# Altium schematic sheet Sheet1.SchDoc(Sheet1)
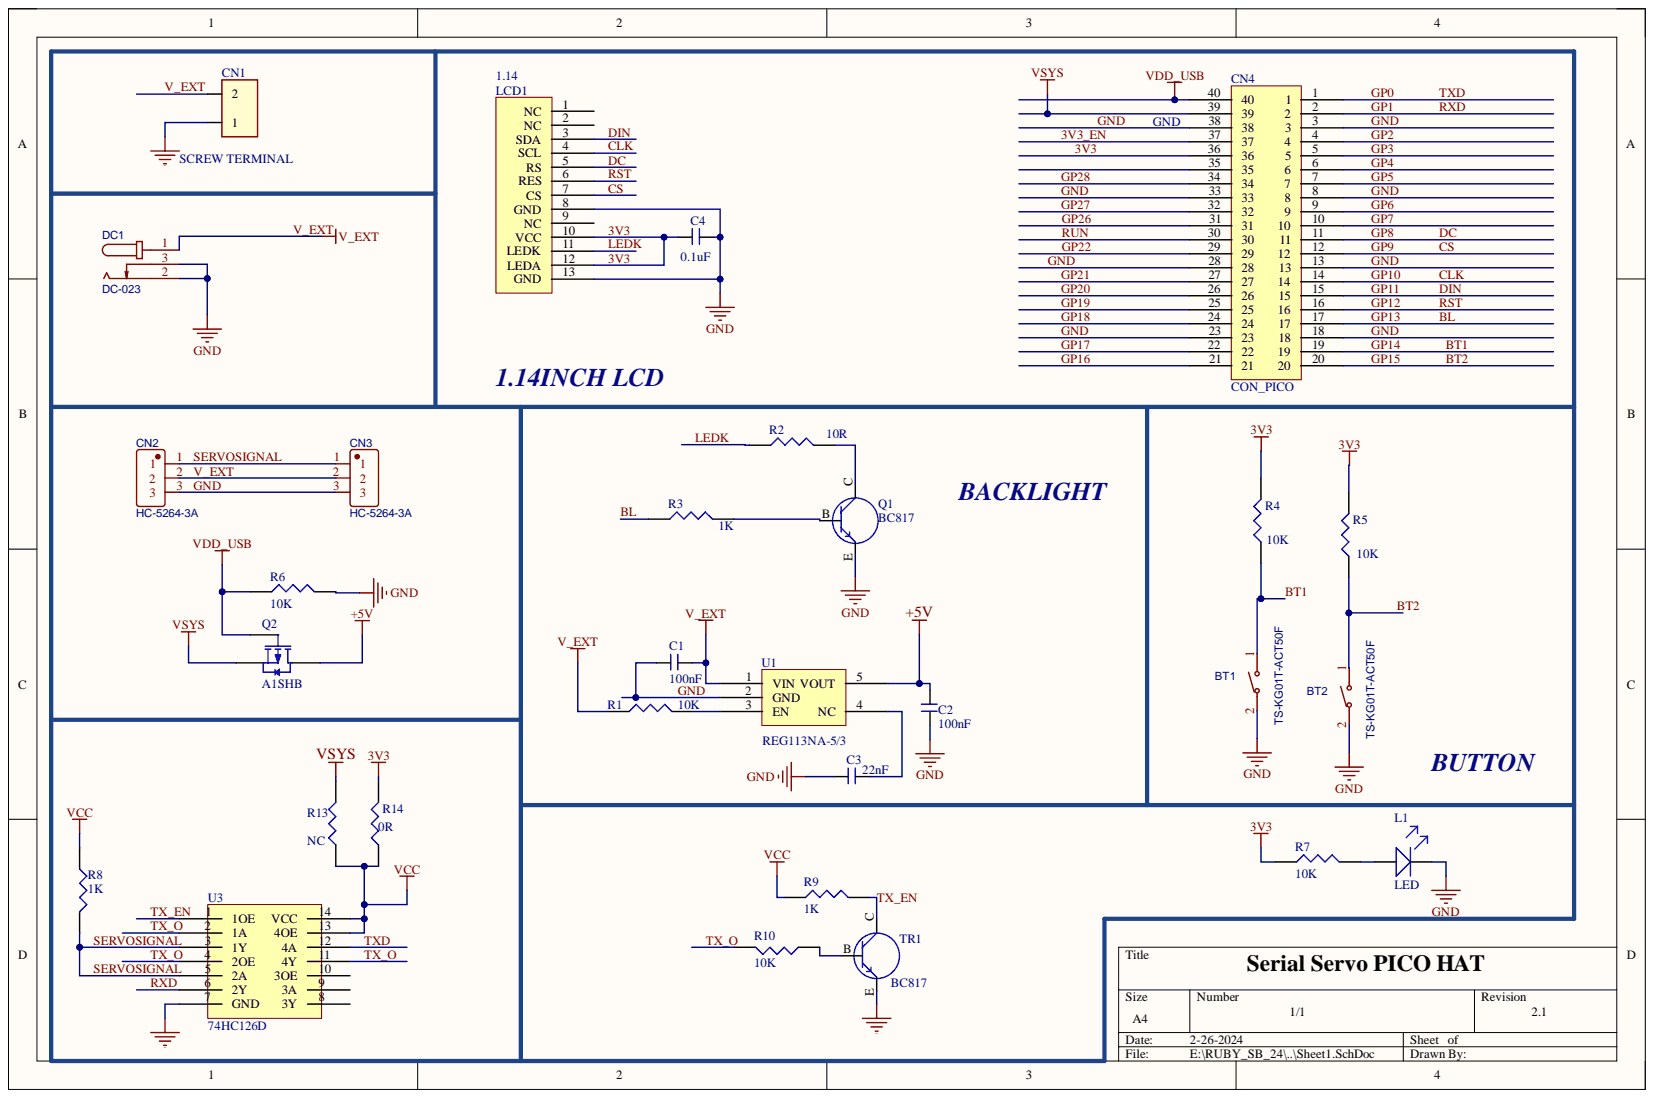
[diagram p0: Sheet1.SchDoc]

## Parts
BT1  TS-KG01T-ACT50F  [SW_2P SMD]
BT2  TS-KG01T-ACT50F  [SW_2P SMD]
C1  100nF  [C_0603]
C2  100nF  [C_0603]
C3  22nF  [C_0603]
C4  0.1uF  [C_0603]
CN1  SCREW TERMINAL  [2P_2.54MM]
CN2  HC-5264-3A  [1X3P P-2.5MM SERVO]
CN3  HC-5264-3A  [1X3P P-2.5MM SERVO]
CN4  CON_PICO  [SMD_PICO HAT HEADER]
DC1  DC-023  [DC-JACK_DC023]
L1  LED  [LED_0603]
LCD1  1.14  [1.14 inch LCD]
Q1  BC817  [SOT23]
Q2  A1SHB  [BSS84]
R1  10K  [R_0603]
R2  10R  [R_0603]
R3  1K  [R_0603]
R4  10K  [R_0603]
R5  10K  [R_0603]
R6  10K  [R_0603]
R7  10K  [R_0603]
R8  1K  [0603]
R9  1K  [R_0603]
R10  10K  [R_0603]
R13  NC  [R_0603]
R14  0R  [R_0603]
TR1  BC817  [SOT23]
U1  REG113NA-5/3  [SOT23-5]
U3  74HC126D  [SOIC-14]

## Nets
+5V: C2.1 Q2.3 U1.5(VOUT)
VCC: R8.1 R9.1 R13.1 R14.1 U3.13(4OE) U3.14(VCC)
VDD_USB: CN4.40 Q2.1 R6.1
VSYS: CN4.39 Q2.2 R13.2
3V3: C4.2 CN4.36 LCD1.10(VCC) LCD1.12(LEDA) R4.2 R5.2 R7.1 R14.2
3V3_EN: CN4.37
BL: CN4.17 R3.1
BT1: BT1.1 CN4.19 R4.1
BT2: BT2.1 CN4.20 R5.1
CLK: CN4.14 LCD1.4(SCL)
CS: CN4.12 LCD1.7(CS)
DC: CN4.11 LCD1.5(RS)
DIN: CN4.15 LCD1.3(SDA)
GND: BT1.2 BT2.2 C1.2 C2.2 C3.2 C4.1 CN1.1 CN2.3 CN3.3 CN4.3 CN4.8 CN4.13 CN4.18 CN4.23 CN4.28 CN4.33 CN4.38 DC1.2 DC1.3 L1.K LCD1.8(GND) LCD1.13(GND) Q1.E R6.2 TR1.E U1.2(GND) U3.7(GND)
GP0: CN4.1 U3.12(4A)
GP1: CN4.2 U3.6(2Y)
GP2: CN4.4
GP3: CN4.5
GP4: CN4.6
GP5: CN4.7
GP6: CN4.9
GP7: CN4.10
GP12: CN4.16 LCD1.6(RES)
GP16: CN4.21
GP17: CN4.22
GP18: CN4.24
GP19: CN4.25
GP20: CN4.26
GP21: CN4.27
GP22: CN4.29
GP26: CN4.31
GP27: CN4.32
GP28: CN4.34
LEDK: LCD1.11(LEDK) R2.1
RUN: CN4.30
SERVOSIGNAL: CN2.1 CN3.1 R8.2 U3.3(1Y) U3.5(2A)
TX_EN: R9.2 TR1.C U3.1(1OE)
TX_O: R10.2 U3.2(1A) U3.4(2OE) U3.11(4Y)
V_EXT: C1.1 CN1.2 CN2.2 CN3.2 DC1.1 R1.1 U1.1(VIN)
NetC3_1: C3.1 U1.4(NC)
NetL1_A: L1.A R7.2
NetQ1_B: Q1.B R3.2
NetQ1_C: Q1.C R2.2
NetR1_2: R1.2 U1.3(EN)
NetR10_1: R10.1 TR1.B

Not connected: CN4 35; LCD1 1(NC) 2(NC) 9(NC); U3 8(3Y) 9(3A) 10(3OE)

## Library data of the parts
BT1 BT2 = TS-KG01T-ACT50F: BOM_Manufacturer: HANBO(汉博); Contributor: LCSC; BOM_JLCPCB Part Class: Extended Part; BOM_Manufacturer Part: TS-KG01T-ACT50F; BOM_Supplier: LCSC; BOM_Supplier Part: C2874211; Component Kind: Standard; spicePre: S; spiceSymbolName: TS-KG01T-ACT50F
C1-C4 = CAP_NONPOLAR: Component Kind: Standard; Library Name: dxp_pasl_lib.IntLib
CN1 = CON2: Component Kind: Standard; Library Name: dxp_pasl_lib.IntLib
CN2 CN3 = HC-5264-3A: Manufacturer: HCTL(华灿天禄); Contributor: LCSC; Component Kind: Standard; JLCPCB Part Class: Extended Part; Manufacturer Part: HC-5264-3A; spicePre: C; spiceSymbolName: HC-5264-3A; Supplier: LCSC; Supplier Part: C2845815
CN4 = CON40_PICO TOP MALE BERG: Component Kind: Standard; Library Name: dxp_pasl_lib.IntLib
DC1 = DC_JACK: BOM_Manufacturer: XKB Connectivity(中国星坤); Contributor: LCSC; BOM_JLCPCB Part Class: Extended Part; BOM_Manufacturer Part: DC-023; BOM_Supplier: LCSC; BOM_Supplier Part: C2689666; Component Kind: Standard; Description: DC-023; spicePre: D; spiceSymbolName: DC-023; Library Name: MIX.SchLib
LCD1 = LCD 1.14INCH: 1.14inch LCD Display 65K RGB Colors, 240×135 Pixels, SPI Interface; Component Kind: Standard; Library Name: dxp_pasl_lib.IntLib
Q1 TR1 = TRANSISTER_NPN: Component Kind: Standard; Library Name: dxp_pasl_lib.IntLib
Q2 = BSS84: 50V 130mA 250mW 10Ω 10V,130mA 2V 1mA P Channel SOT-23(SOT-23-3) MOSFETs ROHS #C493579; PackageDocument: 1995/1996; CATEGORY: MOSFET; Component Kind: Standard; LatestRevisionDate: 17-Jul-2002; LatestRevisionNote: Re-released for DXP Platform.; PackageReference: E3; Published: 8-Jun-2000; Library Name: dxp_pasl_lib.IntLib; Publisher: Altium Limited
R1-R10 R13 R14 = RES: Component Kind: Standard; Library Name: dxp_pasl_lib.IntLib
U1 = AP2112: 600mA CMOS LDO REGULATOR WITH ENABLE SOT-23-5 PACKAGE; Component Kind: Standard; Library Name: dxp_pasl_lib.IntLib
L1 = LED: Component Kind: Standard; Library Name: dxp_pasl_lib.IntLib
U3 = 74HC126D: Component Kind: Standard; Library Name: dxp_pasl_lib.IntLib
